# Altium schematic sheet Antenna_Supervisor.SchDoc(Antenna_Supervisor)
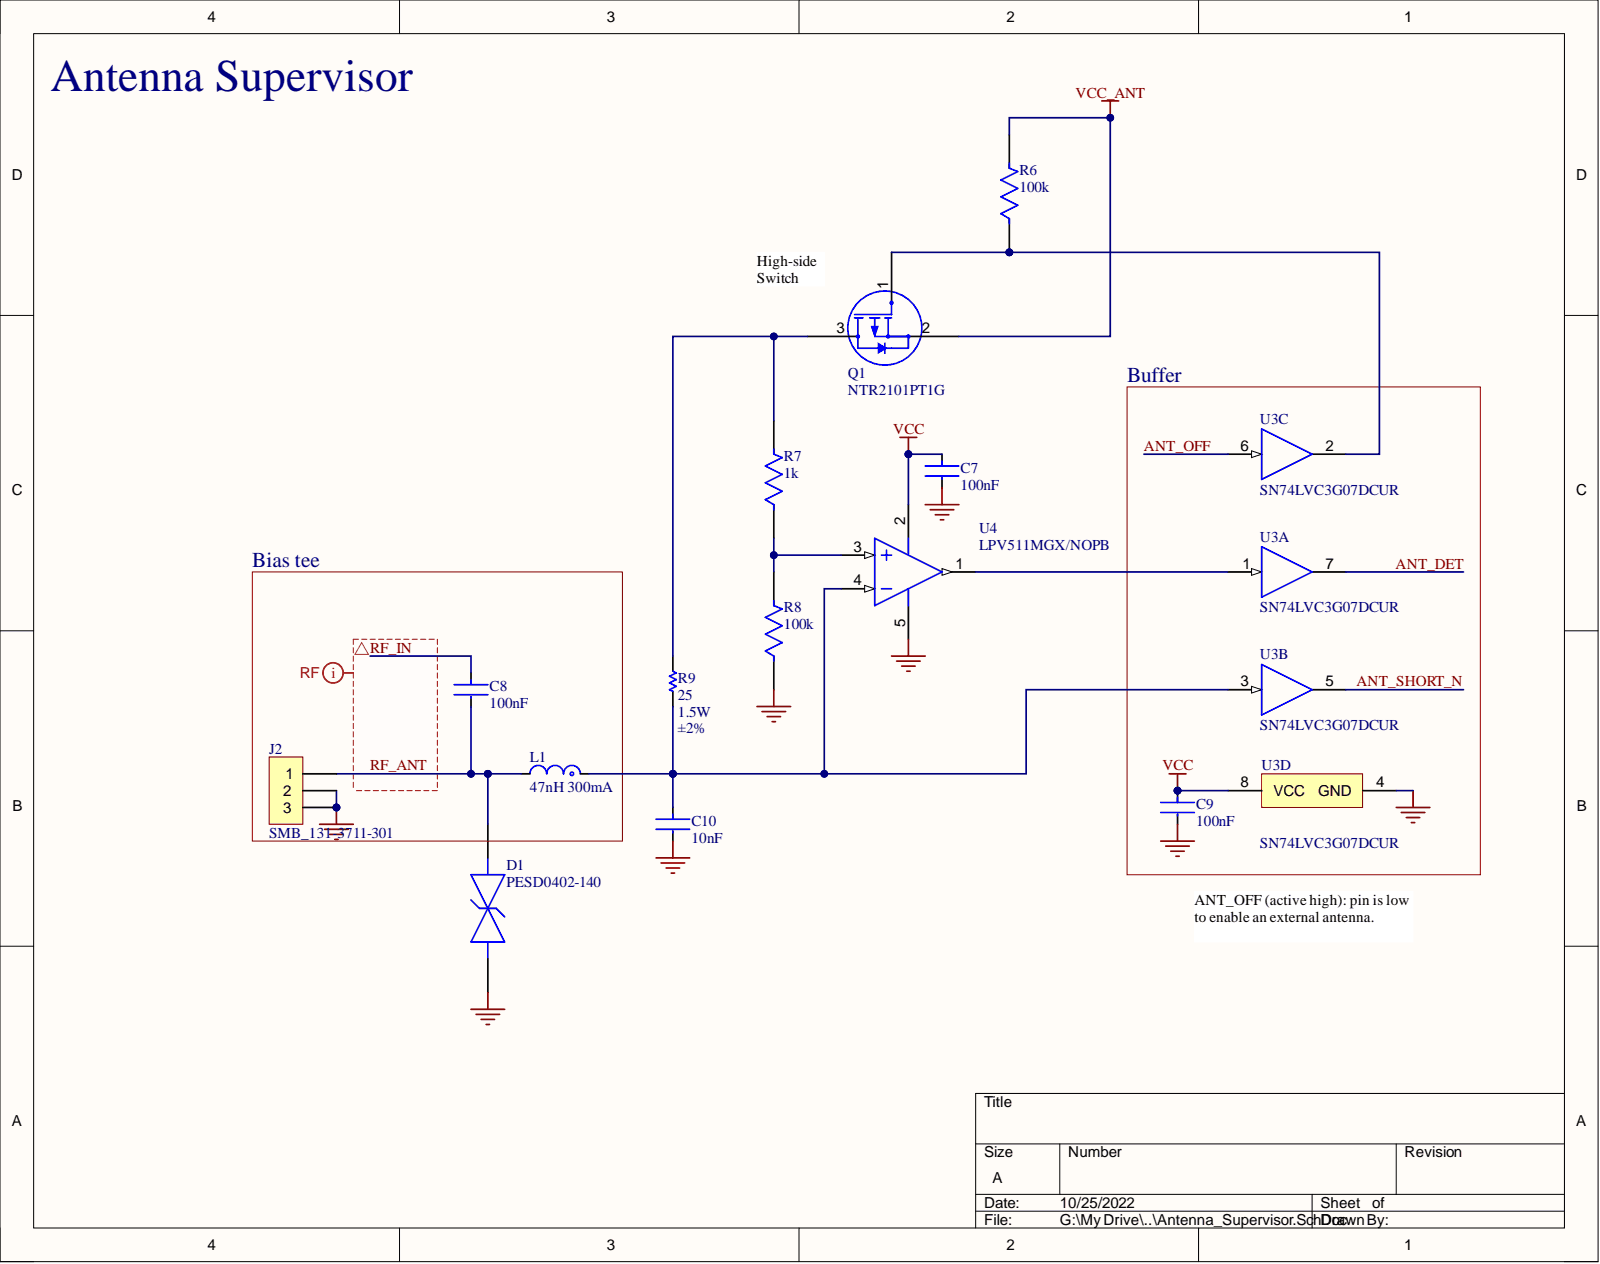
[diagram p0: Antenna_Supervisor.SchDoc]

## Parts
C7  100nF  [FP-0402-L_1_0_0_05-W_0_5-IPC_B]
C8  100nF  [FP-0402-L_1_0_0_05-W_0_5-IPC_B]
C9  100nF  [FP-0402-L_1_0_0_05-W_0_5-IPC_B]
C10  10nF  [FP-GRM033-0_03-IPC_B]
D1  PESD0402-140  [FP-PESD0402-MFG]
J2  SMB_131-3711-301  [CONN_131-3711-301]
L1  47nH 300mA  Inductance: 47nH  [FP-LQG15HH_02-IPC_B]
Q1  Manufacturer Part Number: NTR2101PT1G  [SOT23_3pins_300x140x112]  (hidden comment: onsemi_NTR2101PT1G)
R6  100k  [FP-RMCF0402-IPC_C]
R7  1k  [FP-RMCF0402-MFG]
R8  100k  [FP-RMCF0402-IPC_C]
R9  Power (Watts): 1.5W  Tolerance: ±2%  [RESC1608X055N]  (hidden comment: Vishay_RCP0603W25R0GEB)
U3  SN74LVC3G07DCUR  [DCU0008A_N]  (parts A,B,C,D)
U4  LPV511MGX/NOPB  [DCK0005A_N]

## Nets
GND: C7.2 C9.2 C10.2 D1.1 J2.2 J2.3 R8.1 U3.4(GND) U4.5
VCC: C7.1 C9.1 U3.8(VCC) U4.2
VCC_ANT: Q1.2 R6.2
ANT_DET: U3.7
ANT_OFF: U3.6
ANT_SHORT_N: U3.5
RF_ANT: C8.2 D1.2 J2.1 L1.2
RF_IN: C8.1
NetC10_1: C10.1 L1.1 R9.2 U3.3 U4.4
NetQ1_1: Q1.1 R6.1 U3.2
NetQ1_3: Q1.3 R7.2 R9.1
NetR7_1: R7.1 R8.2 U4.3
NetU3_1: U3.1 U4.1

## Library data of the parts
C7-C9 = CMP-14477-000330-2: None; Dielectric: X5R; Tolerance: 10%; Voltage Rating: 50V; Capacitance: 100nF; Case Code (Imperial): 0402; Case Code (Metric): 1005; Case/Package: 0402; Component Kind: Standard; Halogen Free: Halogen Free; Height: 0.5mm; Length: 0.039inch; Library Name: Altium Content Vault; Max Operating Temperature: 85°C; Min Operating Temperature: -55°C; Mount: Surface Mount; Package Quantity: 10000; Packaging: Tape and Reel; RoHS Compliant: Yes; Simulation: UMK105BJ104_V-F; Termination: SMD/SMT; Thickness: 0.022inch; Voltage Rating (DC): 50V; Width: 0.02inch
C10 = CMP-06035-000448-1: Chip Multilayer Ceramic Capacitors for General Purpose, 0201, 10000pF, X7R, 15%, 10%, 16V; Capacitance: 10nF; Component Kind: Standard; Dielectric: X7R; Length: 600um; Library Name: Altium Content Vault; Thickness: 300um; Tolerance: 10%; Voltage Rating (DC): 16V; Width: 300um
D1 = CMP-08607-000011-1: TVS DIODE 14V 40V 0402; Manufacturer: Littelfuse Inc.; Automotive Grade: Grade 1; Capacitance: 0.25pF; Direction: Bidirectional; Number Of Channels: 1; Value: 0.25pF; Automotive: Yes; Breakdown Voltage: 14V; Category: Diode; Clamping Voltage: 40V; Component Kind: Standard; ComponentLink2Description: Datasheet; ComponentLink2URL: https://www.littelfuse.com/~/media/electronics/datasheets/polymer_esd_suppressors/littelfuse_polymer_esd_suppressor_pesd_catalog_datasheet.pdf.pdf; Device Class L1: Circuit Protection; Device Class L2: TVS Diodes; Device Class L3: unset; Digikey Description: TVS DIODE 14V 40V 0402; Height: 0.43mm; Ipc Land Pattern Name: DIOC10050X33; Lead Free: Yes; Library Name: Altium Content Vault; Manufacturer Part Number: PESD0402-140; Octopart: https://octopart.com/pesd0402-140-littelfuse-74215061; Package: PESD0402; Reverse Standoff Voltage: 14V; RoHS: TRUE; Temperature: -55°C to +125°C; Temperature Range High: +125°C; Temperature Range Low: -55°C
J2 = CONN_131-3711-301: Rf Coaxial Board Mount Connector; Manufacturer: Bel Cinch; Body Material: Brass; Body Plating: Gold; Connector Type: SMB,Male Pin; Dielectric Material: PTFE; Frequency: 4Hz; Gender: Female; Impedance: 50R; Max Frequency: 4GHz; Operating Frequency: 0 to 4 Hz to GHz; Plating: Nickel; Polarity: Reverse; Voltage Rating: 335V; Case/Package: SMA; Category: RF / Coaxial Connectors; Center Contact Plating: Gold; Component Kind: Standard; ComponentLink1Description: http://www.mouser.com/Search/include/RoHSCompliant.aspx?qs=sxnx5FoGSWlI%252bs7K%252boh9Yg%3d%3d&src-supplier=Mouser; ComponentLink1URL: http://www.mouser.com/Search/include/RoHSCompliant.aspx?qs=sxnx5FoGSWlI%252bs7K%252boh9Yg%3d%3d&src-supplier=Mouser; ComponentLink2Description: http://www.mouser.com/Search/include/RoHSCompliant.aspx?qs=BzWiDxlKIe%2fRhe5ZDRBb2Q%3d%3d&src-supplier=Mouser; ComponentLink2URL: http://www.mouser.com/Search/include/RoHSCompliant.aspx?qs=BzWiDxlKIe%2fRhe5ZDRBb2Q%3d%3d&src-supplier=Mouser; ComponentLink3Description: http://www.onlinecomponents.com/datasheet/1313711301.aspx?p=11276490&src-supplier=Onlinecomponents.com; ComponentLink3URL: http://www.onlinecomponents.com/datasheet/1313711301.aspx?p=11276490&src-supplier=Onlinecomponents.com; ComponentLink4Description: http://www.mouser.com/Search/include/RoHSCompliant.aspx?qs=sxnx5FoGSWlgU0HI%252bkNgTA%3d%3d&src-supplier=Mouser; ComponentLink4URL: http://www.mouser.com/Search/include/RoHSCompliant.aspx?qs=sxnx5FoGSWlgU0HI%252bkNgTA%3d%3d&src-supplier=Mouser; ComponentLink5Description: http://www.mouser.com/Search/include/RoHSCompliant.aspx?qs=p2XSgoD%252b2ikka7OcbAcBow%3d%3d&src-supplier=Mouser; ComponentLink5URL: http://www.mouser.com/Search/include/RoHSCompliant.aspx?qs=p2XSgoD%252b2ikka7OcbAcBow%3d%3d&src-supplier=Mouser; ComponentLink6Description: http://www.mouser.com/Search/include/RoHSCompliant.aspx?qs=CoHYZihNMM5AOHZHjTCMkA%3d%3d&src-supplier=Mouser; ComponentLink6URL: http://www.mouser.com/Search/include/RoHSCompliant.aspx?qs=CoHYZihNMM5AOHZHjTCMkA%3d%3d&src-supplier=Mouser; ComponentLink7Description: http://www.mouser.com/Search/include/RoHSCompliant.aspx?qs=hJAXt0VUbWsyDbF0CdNvSg%3d%3d&src-supplier=Mouser; ComponentLink7URL: http://www.mouser.com/Search/include/RoHSCompliant.aspx?qs=hJAXt0VUbWsyDbF0CdNvSg%3d%3d&src-supplier=Mouser; Contact Material: Brass; Contact Plating: Gold,Nickel; Fastening Type: SNAP-ON; Housing Color: Gold; Library Name: MyLibrary.IntLib; Manufacturer Part Number: 131-3711-301; Max Operating Temperature: 165°C; Min Operating Temperature: -65°C; Mount: Surface Mount; Orientation: Right Angle; Package Quantity: 25; Packaging: Bulk; Pricing 1: 1=7.75000, 10=7.00900, 25=6.62160, 50=6.46000, 100=6.13700, 250=5.49100, 500=5.16800, 1000=4.52200 (USD); RoHS: Yes; Shell Plating: Nickel; Stock 1: 475; Supplier 1: Digi-Key; Supplier Part Number 1: J10507-ND; Termination: Solder
L1 = CMP-06042-015783-1: Multilayer type RF Inductor 47nH ±5% 0.72Ω 300mA 0402; Core Material: Air; Current Rating: 200mA; DC Resistance (DCR): 720mR; Q Factor: 8; Self Resonant Frequency: 1GHz; Shielding: Unshielded; Test Frequency: 100MHz; Case Code (Imperial): 0402; Case Code (Metric): 1005; Case/Package: 0402; Component Kind: Standard; DC Current: 200mA; Depth: 0.55mm; Height: 0.5mm; Height - Seated (Max): 0.022inch; Lead Free: Lead Free; Length: 1mm; Library Name: Altium Content Vault; Max DC Current: 200mA; Max Operating Temperature: 125°C; Military Standard: Not; Min Operating Temperature: -55°C; Mount: Surface Mount; Package Quantity: 1; Packaging: Tape and Reel; Radiation Hardening: No; Rated Current-Max: 200mA; Series: LQG15HS; Termination: SMD/SMT; Tolerance: 5%; Width: 0.02inch
Q1 = XQR-CMP-00012-1: MOSFET, P-CH, 8VDS, 3.7A ID, 0.052 OHM RDS(ON)@4.5VGS/3.5A, SOT-23-3; Internal Part Number: 839-00735-00; Manufacturer: onsemi; Current - Continuous Drain (Id) @ 25°C: 3.7A (Ta); Drain to Source Voltage (Vdss): 8 V; Drive Voltage (Max Rds On, Min Rds On): 1.8V, 4.5V; FET Type: P-Channel; Input Capacitance (Ciss) (Max) @ Vds: 1173 pF @ 4 V; Power Dissipation (Max): 960mW (Ta); Rds On (Max) @ Id, Vgs: 52mOhm @ 3.5A, 4.5V; Case/Package: TO-236-3, SC-59, SOT-23-3; Category: FETs, MOSFETs - Single; Component Kind: Standard; Datasheet URL: https://www.digikey.com/en/products/detail/onsemi/ntr2101pt1g/687095; Max Operating Temperature: 150°C; Min Operating Temperature: -55°C; Mounting Type: Surface Mount; RoHS: Yes; Supplier 1: Digikey; Supplier Part Number 1: NTR2101PT1GOSCT-ND; Technology: MOSFET (Metal Oxide); Library Name: RL Research Altium Server
R6 R8 = CMP-2000-06984-2: 100kΩ ±1% 0.063W 0402 Thick Film Chip Resistor AEC-Q200 compliant; Max Power Dissipation: 63mW; Power Rating: 62.5mW; Resistance: 100kR; Temperature Coefficient: 100ppm/°C; Case Code (Imperial): 0402; Case Code (Metric): 1005; Case/Package: 0402; Component Kind: Standard; Construction: Rectangular; Contact Plating: Tin; Depth: 0.5mm; Features: Automotive AEC-Q200; Height: 0.016inch; Lead Free: Lead Free; Length: 1mm; Library Name: Altium Content Vault; Max Operating Temperature: 155°C; Military Standard: Not; Min Operating Temperature: -55°C; Mount: Surface Mount; Number of Terminations: 2; Packaging: Tape and Reel; Radiation Hardening: No; Resistor Type: Thick Film; RoHS Compliant: Yes; Series: RMCF; Size Code: 0402; Tolerance: 1%; Width: 0.02inch
R7 = CMP-26527-000026-1: 1kΩ ±1% 0.063W 0402 Thick Film Chip Resistor AEC-Q200 compliant; Max Power Dissipation: 63mW; Resistance: 1kR; Temperature Coefficient: 100ppm/°C; Case Code (Imperial): 0402; Case Code (Metric): 1005; Case/Package: 0402; Component Kind: Standard; Construction: Rectangular; Contact Plating: Tin; Depth: 0.5mm; Features: Automotive AEC-Q200; Height: 0.016inch; Lead Free: Lead Free; Length: 1mm; Library Name: Altium Content Vault; Max Operating Temperature: 155°C; Military Standard: Not; Min Operating Temperature: -55°C; Mount: Surface Mount; Number of Terminations: 2; Packaging: Tape and Reel; Power Rating: 63mW; Radiation Hardening: No; Resistor Type: Thick Film; RoHS Compliant: Yes; Series: RMCF; Size Code: 0402; Tolerance: 1%; Width: 0.02inch
R9 = RES-CMP-00725-3: RES, 25 OHM, 2%, 1.5W, 150PPM/C, 0603; Internal Part Number: 822-00198-00; Manufacturer: Vishay; Resistance: 25; Temperature Coefficient: ±150ppm/°C; Case/Package: 0603 (1608 Metric); Category: Chip Resistor - Surface Mount; Component Kind: Standard; Composition: Thick Film; Datasheet URL: https://www.digikey.com/en/products/detail/vishay-dale/RCP0603W25R0GEB/5481868?s=N4IgTCBcDaIEoGEAKAGAbCgzAdTAVjhQHEBRAIRAF0BfIA; Features: Moisture Resistant; Height: 0.023" (0.58mm); Manufacturer Part Number: RCP0603W25R0GEB; Max Operating Temperature: 155°C; Min Operating Temperature: -65°C; RoHS: Yes; Series: RCP; Size / Dimension: 0.063" L x 0.032" W (1.60mm x 0.81mm); Supplier 1: Digikey; Supplier Part Number 1: 541-2654-1-ND; Library Name: RL Research Altium Server
U3 = CMP-0859-00615-3: Triple Buffer/Driver With Open-Drain Output, DCU0008A, LARGE T&R; Manufacturer: Texas Instruments; 3-State Output: No; Bits(#): 3; F @ Nom Voltage(Max)(Mhz): 150; ICC @ Nom Voltage(Max)(mA): 0.01; Input Type: CMOS/TTL; Logic: True; Output Drive (IOL/IOH)(Max)(mA): 32/-32; Output Type: CMOS; Rating: Catalog; Sub-Family: Non-Inverting Buffer/Driver; Technology Family: LVC; tpd @ Nom Voltage(Max)(ns): 8.3,4.8,4.2,3.4; VCC(Max)(V): 5.5; VCC(Min)(V): 1.65; Voltage(Nom)(V): 1.8,2.5,3.3,5; Component Kind: Standard; DatasheetVersion: SCES365K; Generic Part Number: SN74LVC3G07; Library Name: Altium Content Vault; Operating Temperature Range(C): -40 to 125,-40 to 85; PackageReference: DCU0008A; Schmitt Trigger: No
U4 = CMP-0055-01065-3: micropower Rail-to-Rail Input and Output Operational Amplifier, 5-pin SC-70, Pb-Free; Manufacturer: Texas Instruments; CompType: Amplifiers; PackageTopMarking: A91*XTT; PowerWise: Y; Code_IPC: SOT353-5; Code_JEDEC: MO-203-AA; Code_JEITA: SC-88A; Component Kind: Standard; ComponentLink1Description: Datasheet URL; ComponentLink1URL: http://www.ti.com/general/docs/lit/getliterature.tsp?genericPartNumber=LPV511&fileType=pdf; Library Name: Altium Content Vault; Package Reference: DCK0005A; PackageDescription: Molded SC-70, 2 x 1.25 x .9mm, 5 Lead, 0.65mm Pitch; PinCount: 5; RoHS: Y
